# Altium schematic sheet Extra8_i2c.SchDoc
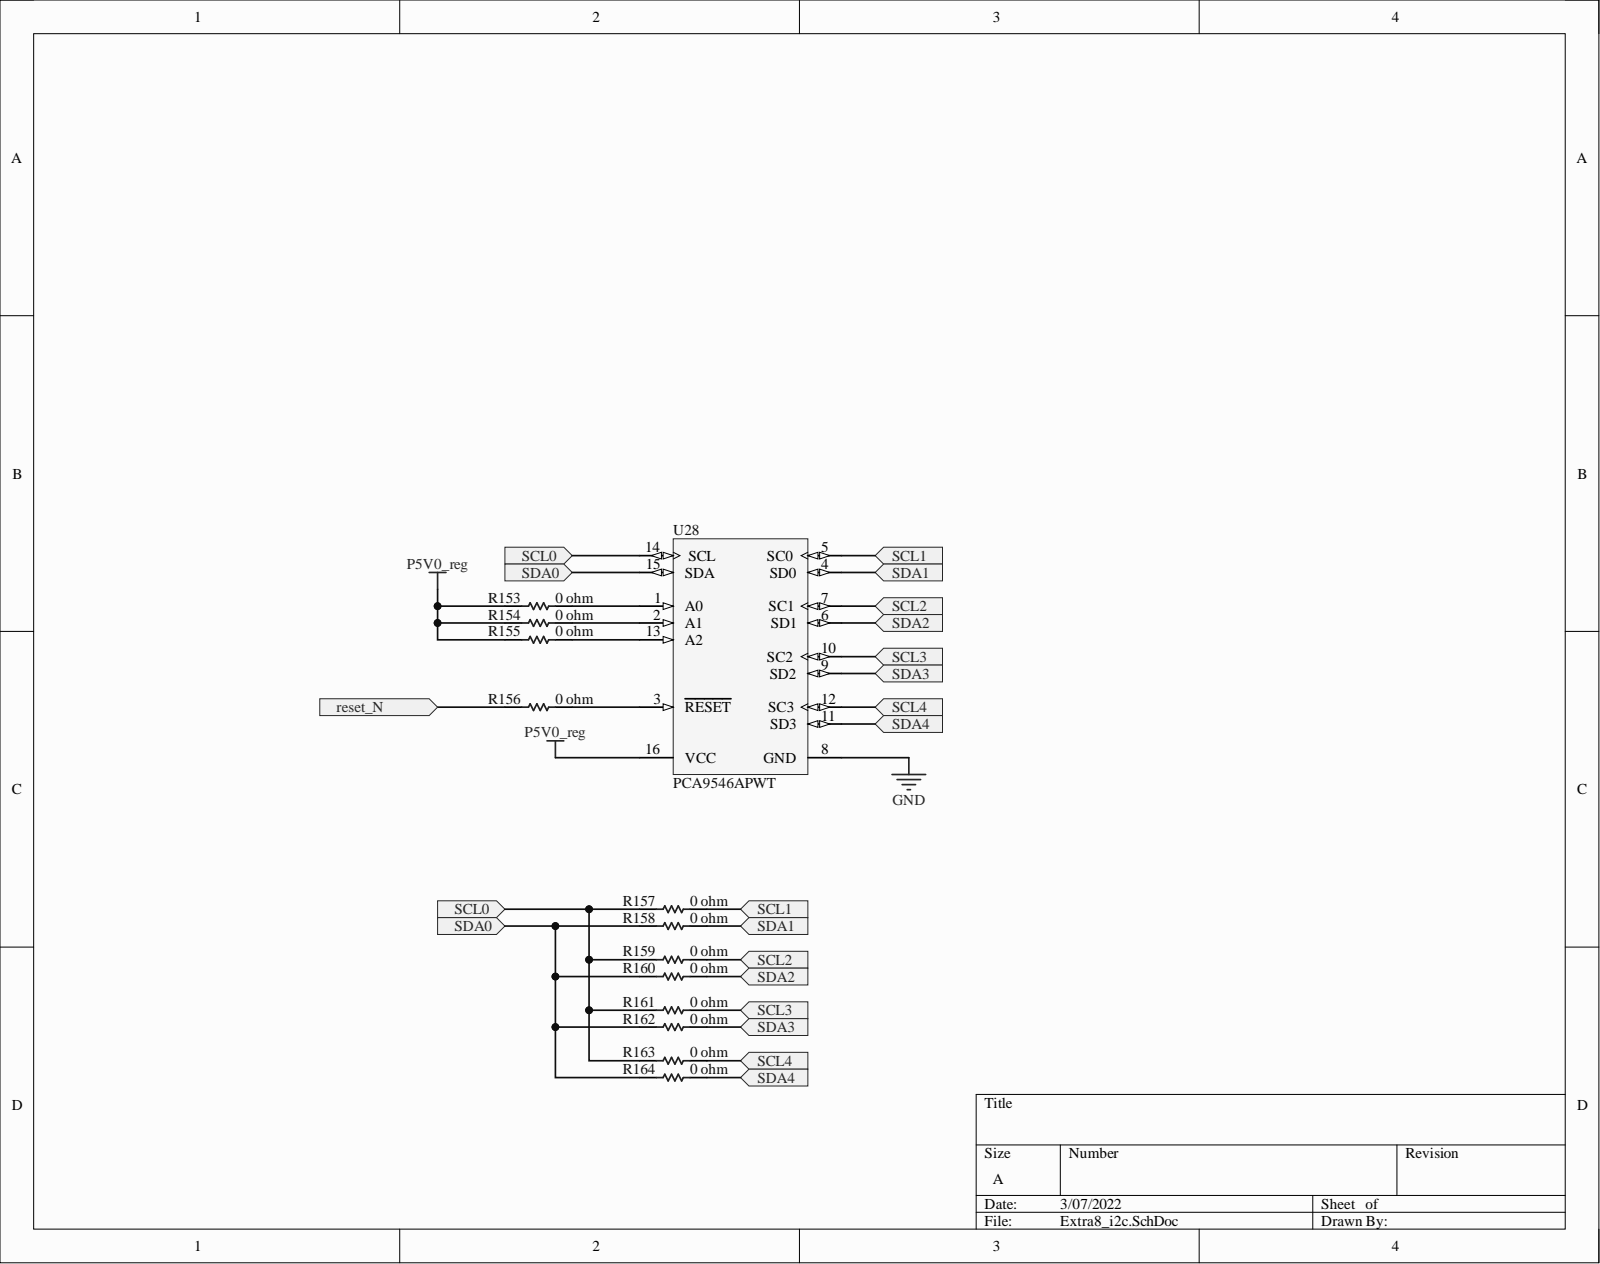
[diagram p0: Extra8_i2c.SchDoc]

## Parts
R153  0 ohm  [RESC2013X50X30LL20T20]
R154  0 ohm  [RESC2013X50X30LL20T20]
R155  0 ohm  [RESC2013X50X30LL20T20]
R156  0 ohm  [RESC2013X50X30LL20T20]
R157  0 ohm  [RESC2013X50X30LL20T20]
R158  0 ohm  [RESC2013X50X30LL20T20]
R159  0 ohm  [RESC2013X50X30LL20T20]
R160  0 ohm  [RESC2013X50X30LL20T20]
R161  0 ohm  [RESC2013X50X30LL20T20]
R162  0 ohm  [RESC2013X50X30LL20T20]
R163  0 ohm  [RESC2013X50X30LL20T20]
R164  0 ohm  [RESC2013X50X30LL20T20]
U28  PCA9546APWT  [PW16_M]

## Ports
reset_N, SCL0, SCL1, SCL2, SCL3, SCL4, SDA0, SDA1, SDA2, SDA3, SDA4

## Nets
GND: U28.8(GND)
P5V0_reg: R153.1 R154.1 R155.1 U28.16(VCC)
reset_N: R156.1 ; port reset_N
SCL0: R157.1 R159.1 R161.1 R163.1 U28.14(SCL) ; port SCL0
SCL1: R157.2 U28.5(SC0) ; port SCL1
SCL2: R159.2 U28.7(SC1) ; port SCL2
SCL3: R161.2 U28.10(SC2) ; port SCL3
SCL4: R163.2 U28.12(SC3) ; port SCL4
SDA0: R158.1 R160.1 R162.1 R164.1 U28.15(SDA) ; port SDA0
SDA1: R158.2 U28.4(SD0) ; port SDA1
SDA2: R160.2 U28.6(SD1) ; port SDA2
SDA3: R162.2 U28.9(SD2) ; port SDA3
SDA4: R164.2 U28.11(SD3) ; port SDA4
NetR153_2: R153.2 U28.1(A0)
NetR154_2: R154.2 U28.2(A1)
NetR155_2: R155.2 U28.13(A2)
NetR156_2: R156.2 U28.3(RESET)

## Library data of the parts
R153-R164 = CMP-1904075-2: RES SMD 0.0 OHM JUMPER 1/8W 0805; Manufacturer Part Number: CRCW08050000ZSTA; Case/Package (SI): 2012; Lead-Free Status: Contains Lead; Power Dissipation: 0.125 W; Resistance: 0.0 Ω; Resistance Tolerance: ±1%; Voltage Rating (DC): 150.0 V; Component Kind: Standard; Library Name: My Personal Space; Mounting Style: Surface Mount; Number of Pins: 2; Octopart Url: https://octopart.com/crcw08050000zsta-vishay-39388113; OctopartID: 3b91f0c21d26e456; Power Rating: 0.125 W; REACH SVHC Compliance: No SVHC; RoHS: Non-Compliant; Size-Height: 0.00045 m; Size-Length: 0.002 m; Size-Width: 0.00125 m
U28 = CMP-4940578-2: 4-Channel I2C and SMBus Multiplexer with Reset Functions, 2.3 to 5.5 V, -40 to 85 degC, 16-pin TSSOP (PW), Green (RoHS & no Sb/Br); Lead-Free Status: Lead Free; Number of Channels: 4; Propagation Delay Max (tpd): 1e-09 s; Supply Current: 3e-06 A; Case/Package: TSSOP; Component Kind: Standard; Library Name: My Personal Space; Lifecycle Status: Active; Manufacturer Part Number: PCA9546APWT; Mounting Style: Surface Mount; Number of Pins: 16; Octopart Url: https://octopart.com/pca9546apwt-texas+instruments-711813; OctopartID: 6573ac739c90bab4; Packaging: Cut Tape (CT); REACH SVHC Compliance: No SVHC; RoHS: Compliant
